# Altium schematic sheet Sheet1.SchDoc
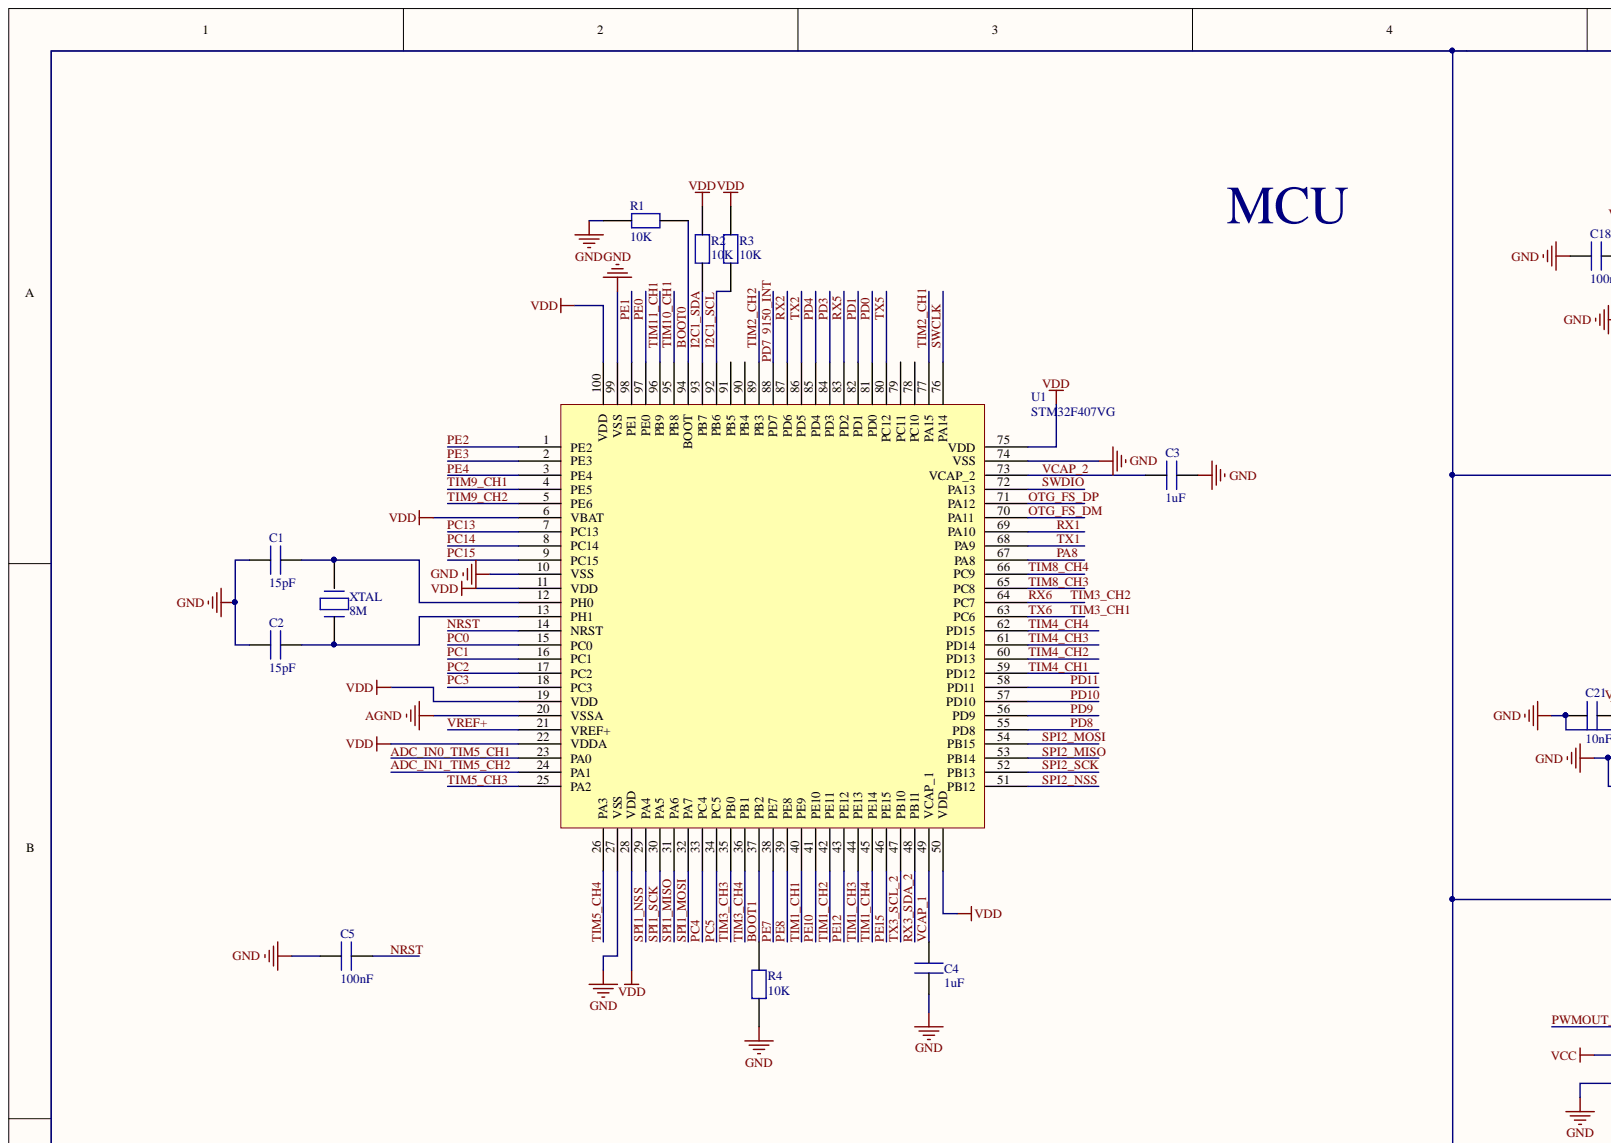
[diagram p0: Sheet1.SchDoc, top left]
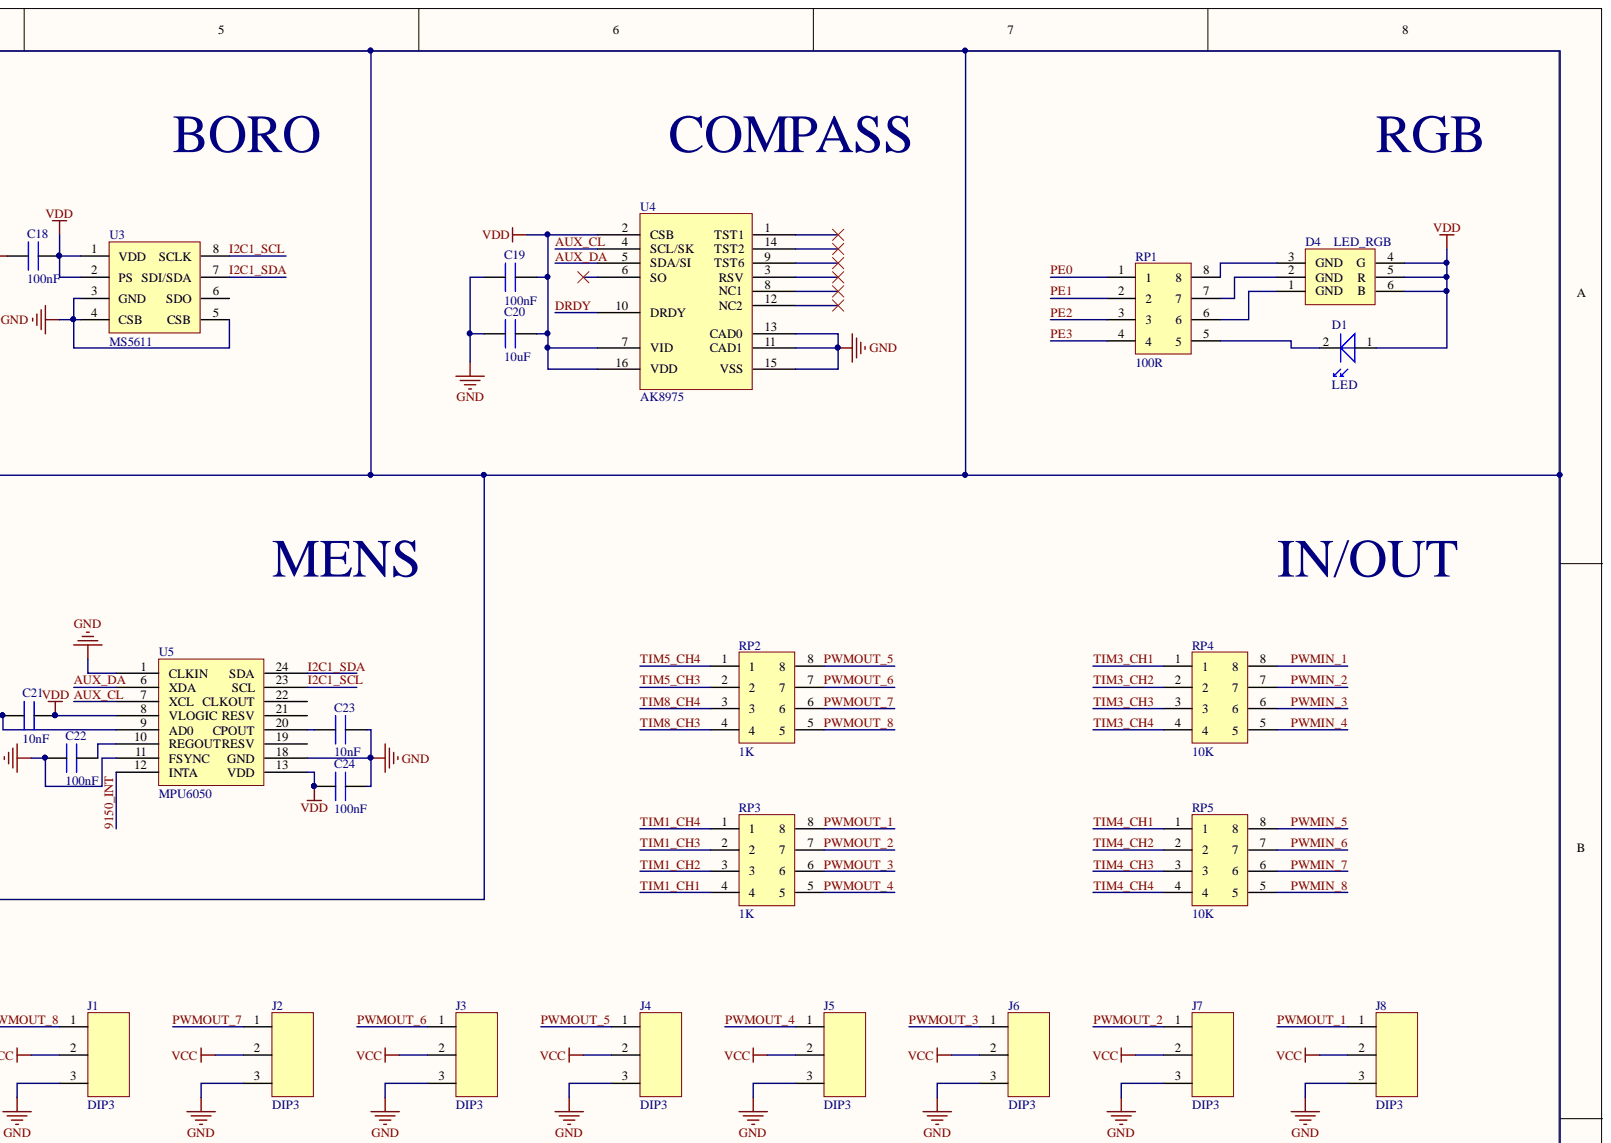
[diagram p1: Sheet1.SchDoc, top right]
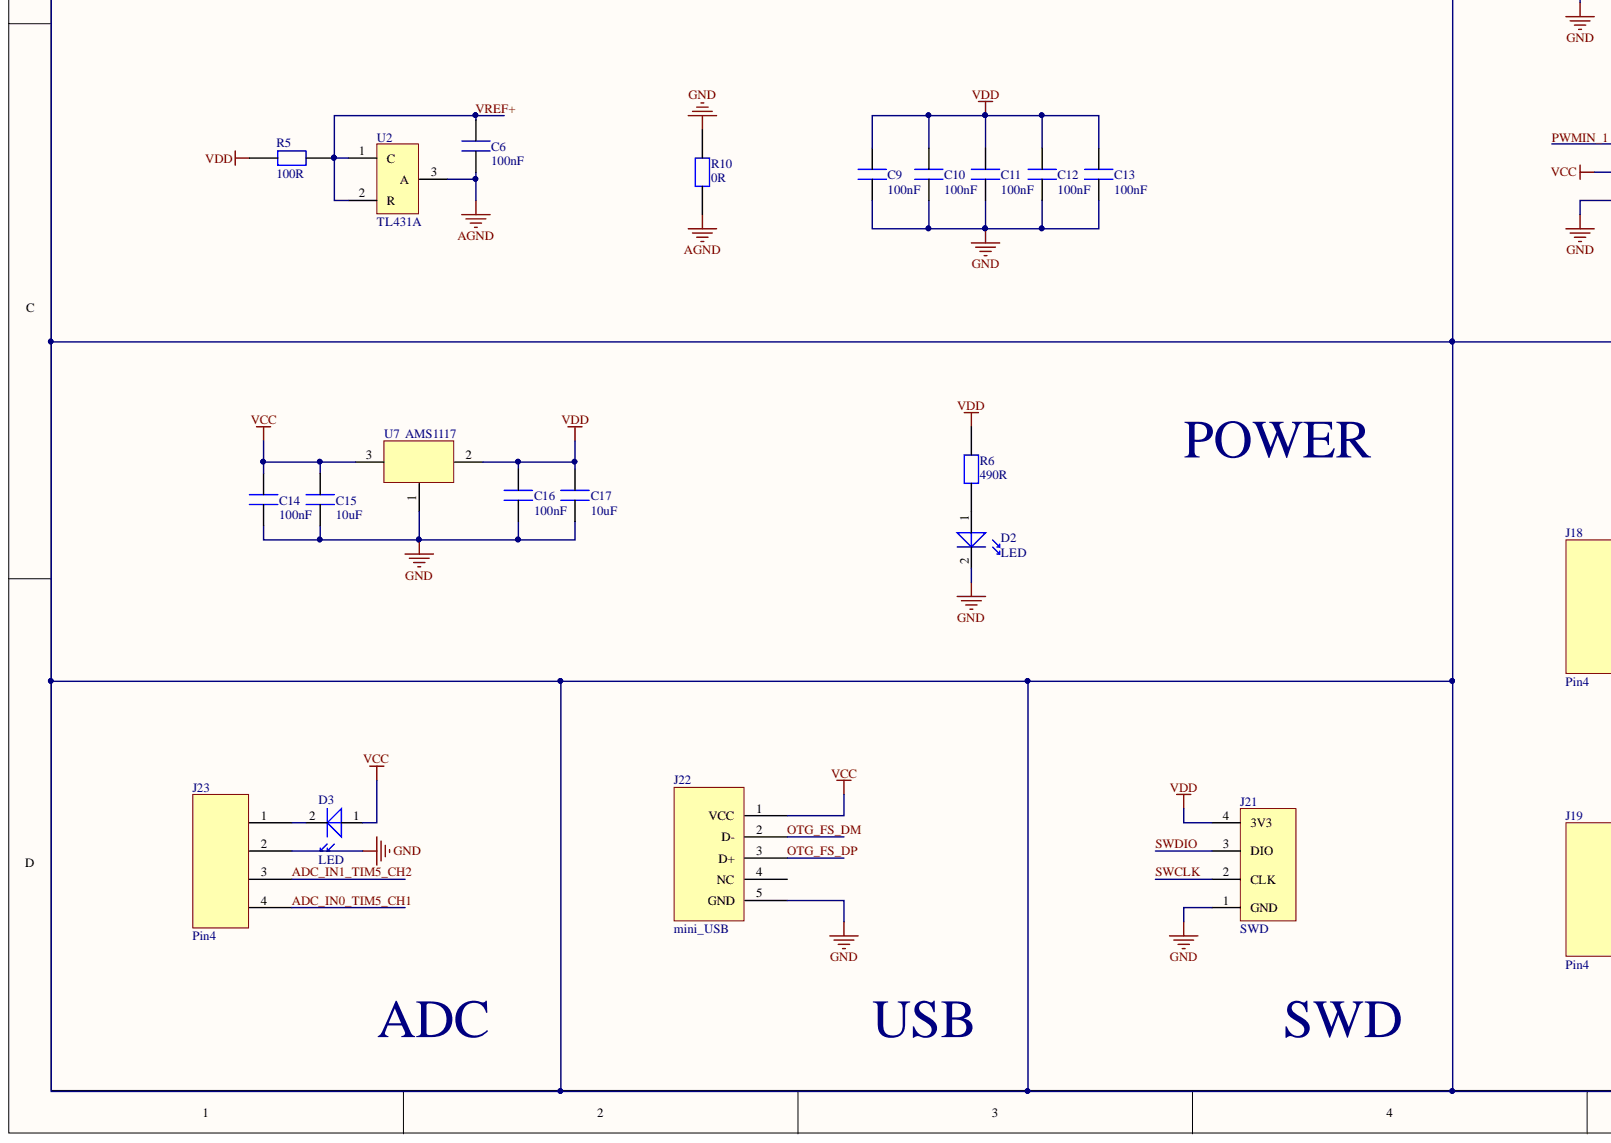
[diagram p2: Sheet1.SchDoc, bottom left]
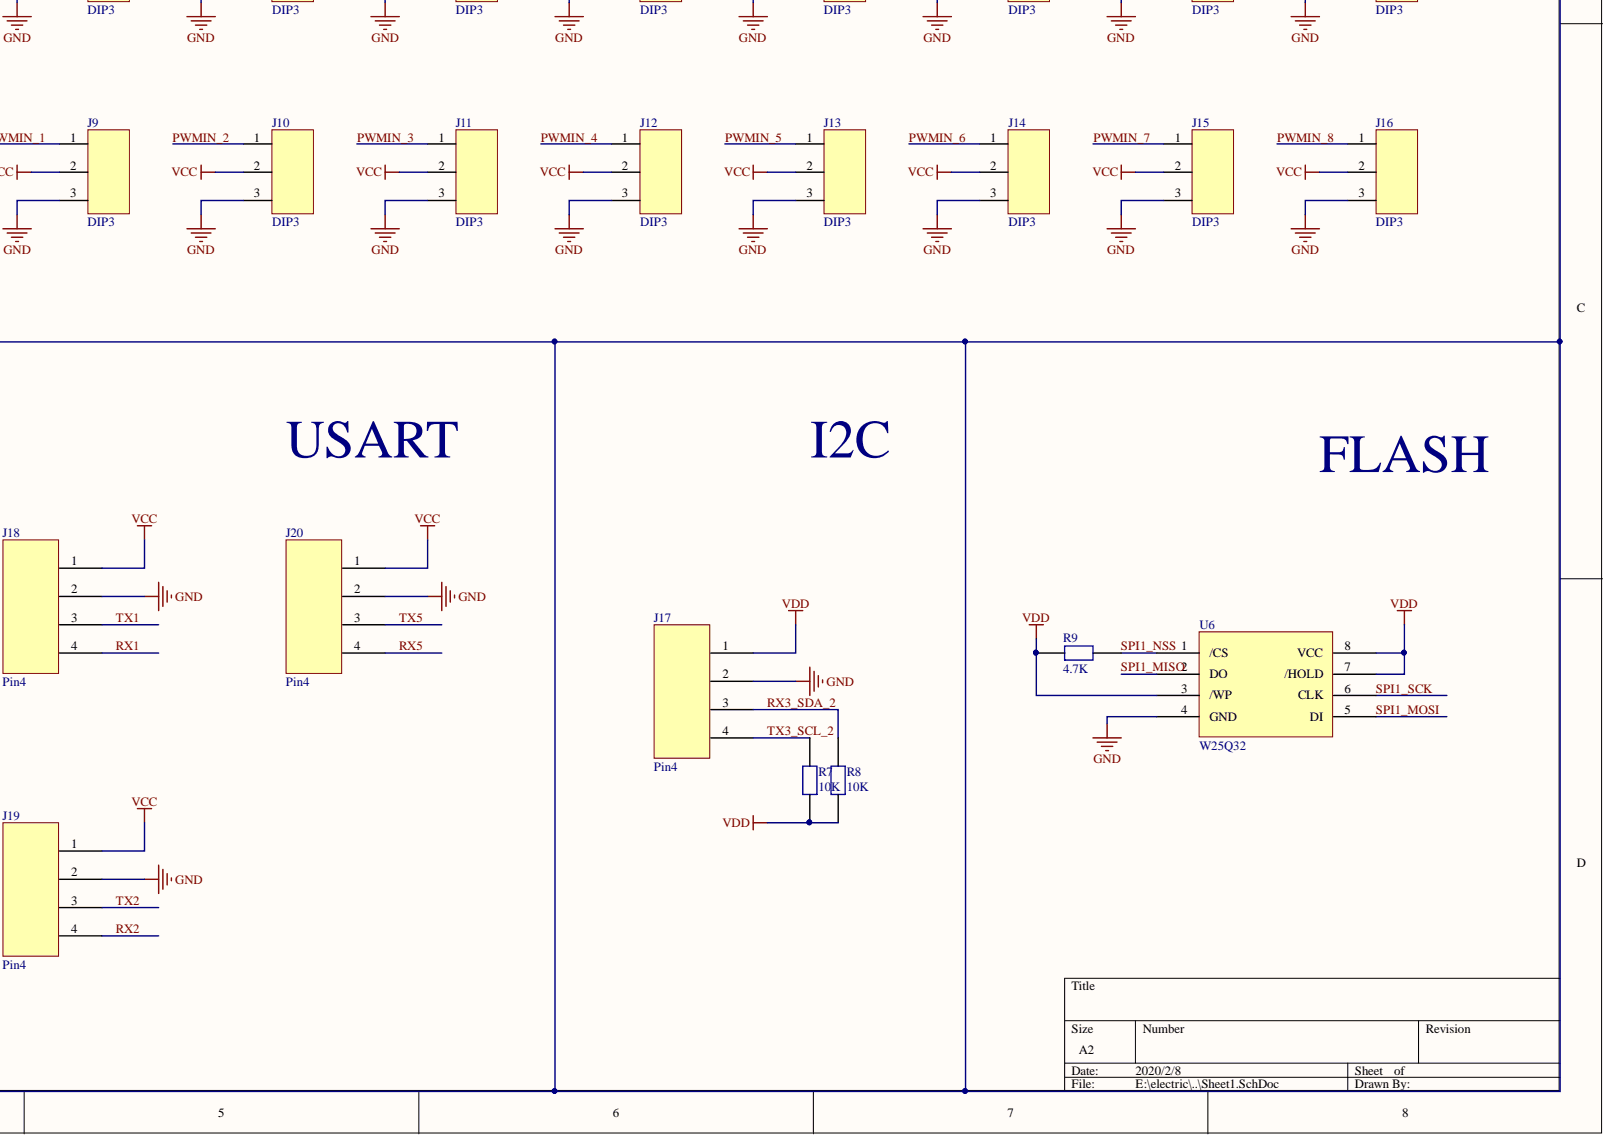
[diagram p3: Sheet1.SchDoc, bottom right]

## Parts
C1  15pF  [06_03]
C2  15pF  [06_03]
C3  1uF  [06_03]
C4  1uF  [06_03]
C5  100nF  [06_03]
C6  100nF  [06_03]
C9  100nF  [06_03]
C10  100nF  [06_03]
C11  100nF  [06_03]
C12  100nF  [06_03]
C13  100nF  [06_03]
C14  100nF  [06_03]
C15  10uF  [06_03]
C16  100nF  [06_03]
C17  10uF  [06_03]
C18  100nF  [06_03]
C19  100nF  [06_03]
C20  10uF  [06_03]
C21  10nF  [06_03]
C22  100nF  [06_03]
C23  10nF  [06_03]
C24  100nF  [06_03]
D1  LED  [0603]
D2  LED  [0603]
D3  LED  [0603]
D4  LED_RGB  [LED_5050]
J1  DIP3  [DIP3]
J2  DIP3  [DIP3]
J3  DIP3  [DIP3]
J4  DIP3  [DIP3]
J5  DIP3  [DIP3]
J6  DIP3  [DIP3]
J7  DIP3  [DIP3]
J8  DIP3  [DIP3]
J9  DIP3  [DIP3]
J10  DIP3  [DIP3]
J11  DIP3  [DIP3]
J12  DIP3  [DIP3]
J13  DIP3  [DIP3]
J14  DIP3  [DIP3]
J15  DIP3  [DIP3]
J16  DIP3  [DIP3]
J17  Pin4  [DIP_4]
J18  Pin4  [DIP_4]
J19  Pin4  [DIP_4]
J20  Pin4  [DIP_4]
J21  SWD  [DIP_4]
J22  mini_USB  [MINI USB]
J23  Pin4  [DIP_4]
R1  10K  [06_03]
R2  10K  [06_03]
R3  10K  [06_03]
R4  10K  [06_03]
R5  100R  [06_03]
R6  490R  [06_03]
R7  10K  [06_03]
R8  10K  [06_03]
R9  4.7K  [06_03]
R10  0R  [06_03]
RP1  100R  [8P4R]
RP2  1K  [8P4R]
RP3  1K  [8P4R]
RP4  10K  [8P4R]
RP5  10K  [8P4R]
U1  STM32F407VG  [LQFP100]
U2  TL431A  [SOT-23-3L]
U3  MS5611  [MS5611]
U4  AK8975  [QFN16]
U5  MPU6050  [QFN24]
U6  W25Q32  [SOIC-8]
U7  AMS1117  [SOT232]
XTAL  8M  [XTAR1]

## Nets
AGND: C6.1 R10.1 U1.20(VSSA) U2.3
GND: C1.1 C2.1 C3.2 C4.1 C5.1 C9.1 C10.1 C11.1 C12.1 C13.1 C14.1 C15.1 C16.1 C17.1 C18.1 C19.1 C20.1 C21.1 C22.1 C23.2 C24.2 D2.2 J1.3 J2.3 J3.3 J4.3 J5.3 J6.3 J7.3 J8.3 J9.3 J10.3 J11.3 J12.3 J13.3 J14.3 J15.3 J16.3 J17.2 J18.2 J19.2 J20.2 J21.1(GND) J22.5(GND) J23.2 R1.1 R4.1 R10.2 U1.10(VSS) U1.27(VSS) U1.74(VSS) U1.99(VSS) U3.3(GND) U3.4(CSB) U3.5(CSB) U4.11(CAD1) U4.13(CAD0) U4.15(VSS) U5.1(CLKIN) U5.9(AD0) U5.11(FSYNC) U5.18(GND) U6.4(GND) U7.1
VCC: C14.2 C15.2 D3.1 J1.2 J2.2 J3.2 J4.2 J5.2 J6.2 J7.2 J8.2 J9.2 J10.2 J11.2 J12.2 J13.2 J14.2 J15.2 J16.2 J18.1 J19.1 J20.1 J22.1(VCC) U7.3
VDD: C9.2 C10.2 C11.2 C12.2 C13.2 C16.2 C17.2 C18.2 C19.2 C20.2 C21.2 C24.1 D1.1 D4.4(G) D4.5(R) D4.6(B) J17.1 J21.4(3V3) R2.2 R3.2 R5.1 R6.2 R7.1 R8.1 R9.1 U1.6(VBAT) U1.11(VDD) U1.19(VDD) U1.22(VDDA) U1.28(VDD) U1.50(VDD) U1.75(VDD) U1.100(VDD) U3.1(VDD) U3.2(PS) U4.2(CSB) U4.7(VID) U4.16(VDD) U5.8(VLOGIC) U5.13(VDD) U6.3(/WP) U6.7(/HOLD) U6.8(VCC) U7.2
9150_INT: U1.88(PD7) U5.12(INTA)
ADC_IN0_TIM5_CH1: J23.4 U1.23(PA0)
ADC_IN1_TIM5_CH2: J23.3 U1.24(PA1)
AUX_CL: U4.4(SCL/SK) U5.7(XCL)
AUX_DA: U4.5(SDA/SI) U5.6(XDA)
BOOT0: R1.2 U1.94(BOOT)
BOOT1: R4.2 U1.37(PB2)
DRDY: U4.10(DRDY)
I2C1_SCL: R3.1 U1.92(PB6) U3.8(SCLK) U5.23(SCL)
I2C1_SDA: R2.1 U1.93(PB7) U3.7(SDI/SDA) U5.24(SDA)
NRST: C5.2 U1.14(NRST)
OTG_FS_DM: J22.2(D-) U1.70(PA11)
OTG_FS_DP: J22.3(D+) U1.71(PA12)
PA8: U1.67(PA8)
PC0: U1.15(PC0)
PC1: U1.16(PC1)
PC2: U1.17(PC2)
PC3: U1.18(PC3)
PC4: U1.33(PC4)
PC5: U1.34(PC5)
PC13: U1.7(PC13)
PC14: U1.8(PC14)
PC15: U1.9(PC15)
PD0: U1.81(PD0)
PD1: U1.82(PD1)
PD3: U1.84(PD3)
PD4: U1.85(PD4)
PD8: U1.55(PD8)
PD9: U1.56(PD9)
PD10: U1.57(PD10)
PD11: U1.58(PD11)
PE0: RP1.1 U1.97(PE0)
PE1: RP1.2 U1.98(PE1)
PE2: RP1.3 U1.1(PE2)
PE3: RP1.4 U1.2(PE3)
PE4: U1.3(PE4)
PE7: U1.38(PE7)
PE8: U1.39(PE8)
PE10: U1.41(PE10)
PE12: U1.43(PE12)
PE15: U1.46(PE15)
PWMIN_1: J9.1 RP4.8
PWMIN_2: J10.1 RP4.7
PWMIN_3: J11.1 RP4.6
PWMIN_4: J12.1 RP4.5
PWMIN_5: J13.1 RP5.8
PWMIN_6: J14.1 RP5.7
PWMIN_7: J15.1 RP5.6
PWMIN_8: J16.1 RP5.5
PWMOUT_1: J8.1 RP3.8
PWMOUT_2: J7.1 RP3.7
PWMOUT_3: J6.1 RP3.6
PWMOUT_4: J5.1 RP3.5
PWMOUT_5: J4.1 RP2.8
PWMOUT_6: J3.1 RP2.7
PWMOUT_7: J2.1 RP2.6
PWMOUT_8: J1.1 RP2.5
RX1: J18.4 U1.69(PA10)
RX2: J19.4 U1.87(PD6)
RX3_SDA_2: J17.3 R8.2 U1.48(PB11)
RX5: J20.4 U1.83(PD2)
RX6: RP4.2 U1.64(PC7)
SPI1_MISO: U1.31(PA6) U6.2(DO)
SPI1_MOSI: U1.32(PA7) U6.5(DI)
SPI1_NSS: R9.2 U1.29(PA4) U6.1(/CS)
SPI1_SCK: U1.30(PA5) U6.6(CLK)
SPI2_MISO: U1.53(PB14)
SPI2_MOSI: U1.54(PB15)
SPI2_NSS: U1.51(PB12)
SPI2_SCK: U1.52(PB13)
SWCLK: J21.2(CLK) U1.76(PA14)
SWDIO: J21.3(DIO) U1.72(PA13)
TIM1_CH1: RP3.4 U1.40(PE9)
TIM1_CH2: RP3.3 U1.42(PE11)
TIM1_CH3: RP3.2 U1.44(PE13)
TIM1_CH4: RP3.1 U1.45(PE14)
TIM2_CH1: U1.77(PA15)
TIM2_CH2: U1.89(PB3)
TIM3_CH1: RP4.1 U1.63(PC6)
TIM3_CH3: RP4.3 U1.35(PB0)
TIM3_CH4: RP4.4 U1.36(PB1)
TIM4_CH1: RP5.1 U1.59(PD12)
TIM4_CH2: RP5.2 U1.60(PD13)
TIM4_CH3: RP5.3 U1.61(PD14)
TIM4_CH4: RP5.4 U1.62(PD15)
TIM5_CH3: RP2.2 U1.25(PA2)
TIM5_CH4: RP2.1 U1.26(PA3)
TIM8_CH3: RP2.4 U1.65(PC8)
TIM8_CH4: RP2.3 U1.66(PC9)
TIM9_CH1: U1.4(PE5)
TIM9_CH2: U1.5(PE6)
TIM10_CH1: U1.95(PB8)
TIM11_CH1: U1.96(PB9)
TX1: J18.3 U1.68(PA9)
TX2: J19.3 U1.86(PD5)
TX3_SCL_2: J17.4 R7.2 U1.47(PB10)
TX5: J20.3 U1.80(PC12)
VCAP_1: C4.2 U1.49(VCAP_1)
VCAP_2: C3.1 U1.73(VCAP_2)
VREF+: C6.2 R5.2 U1.21(VREF+) U2.1 U2.2
NetC1_2: C1.2 U1.12(PH0) XTAL.2
NetC2_2: C2.2 U1.13(PH1) XTAL.1
NetC22_2: C22.2 U5.10(REGOUT)
NetC23_1: C23.1 U5.20(CPOUT)
NetD1_2: D1.2 RP1.5
NetD2_1: D2.1 R6.1
NetD3_2: D3.2 J23.1
NetD4_1: D4.1(GND) RP1.6
NetD4_2: D4.2(GND) RP1.7
NetD4_3: D4.3(GND) RP1.8
N00013:

Not connected: J22 4(NC); U1 78(PC10) 79(PC11) 90(PB4) 91(PB5); U3 6(SDO); U4 1(TST1) 3(RSV) 6(SO) 8(NC1) 9(TST6) 12(NC2) 14(TST2); U5 19(RESV) 21(RESV) 22(CLKOUT)

## Library data of the parts
C1-C6 C9-C24 = C: Component Kind: Standard; Library Name: MY_SCH-LIB.SchLib
R1-R10 = R: Component Kind: Standard; Library Name: MY_SCH-LIB.SchLib
RP1-RP5 = 8P4R: Component Kind: Standard; Library Name: MY_SCH-LIB.SchLib
U5 = MUP6050: Component Kind: Standard; Library Name: MY_SCH-LIB.SchLib
XTAL = XTAR1: Component Kind: Standard; Library Name: MY_SCH-LIB.SchLib
D1-D3 = LED: Component Kind: Standard; Library Name: MY_SCH-LIB.SchLib
D4 = LED_RGB: Component Kind: Standard; Library Name: MY_SCH-LIB.SchLib
J1-J16 = DIP3: Component Kind: Standard; Library Name: MY_SCH-LIB.SchLib
J17-J20 J23 = Pin4: Component Kind: Standard; Library Name: MY_SCH-LIB.SchLib
J21 = SWD: Component Kind: Standard; Library Name: MY_SCH-LIB.SchLib
J22 = mini_USB: Component Kind: Standard; Library Name: MY_SCH-LIB.SchLib
U1 = STM32F407VG: Component Kind: Standard; Library Name: MY_SCH-LIB.SchLib
U2 = TL431A: Component Kind: Standard; Library Name: MY_SCH-LIB.SchLib
U3 = MS5611: Component Kind: Standard; Library Name: MY_SCH-LIB.SchLib
U4 = AK8975: Component Kind: Standard; Library Name: MY_SCH-LIB.SchLib
U6 = W25Q32: Component Kind: Standard; Library Name: MY_SCH-LIB.SchLib
U7 = AMS1117: Component Kind: Standard; Library Name: MY_SCH-LIB.SchLib
